# Altium schematic sheet BNT_Asma_Amp.SchDoc
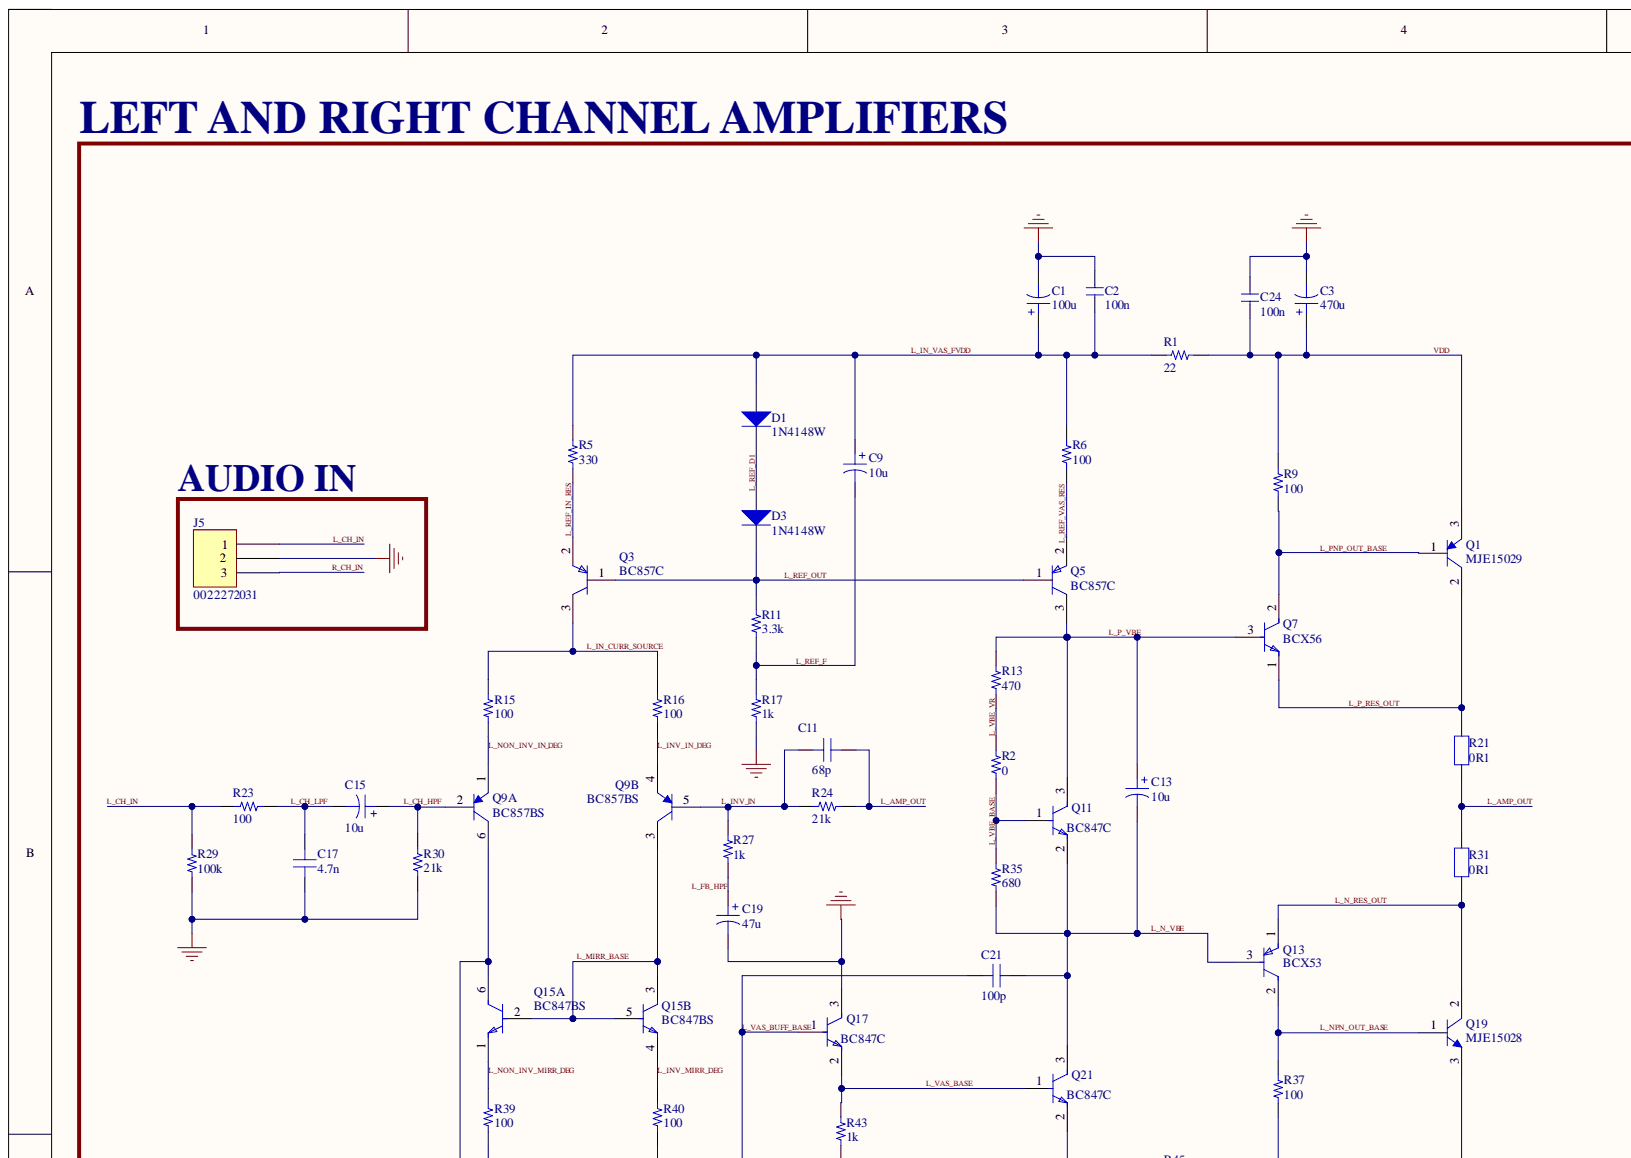
[diagram p0: BNT_Asma_Amp.SchDoc, top left]
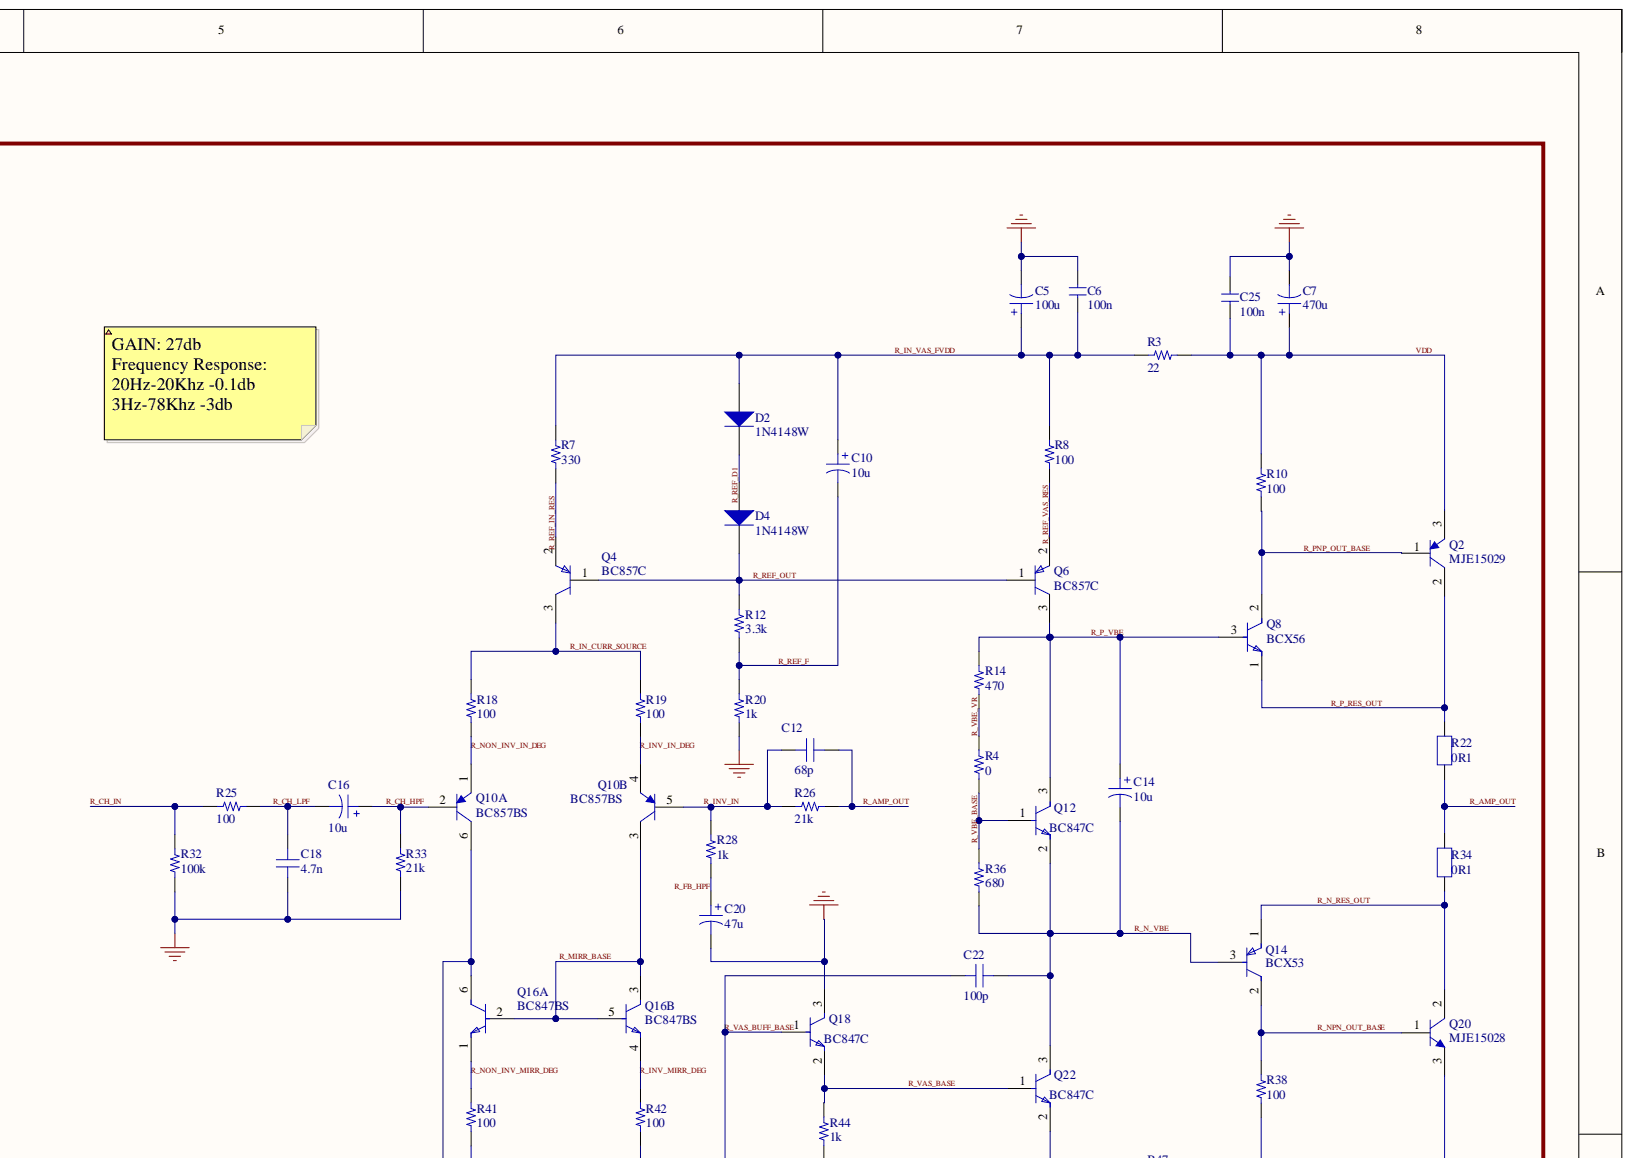
[diagram p1: BNT_Asma_Amp.SchDoc, top right]
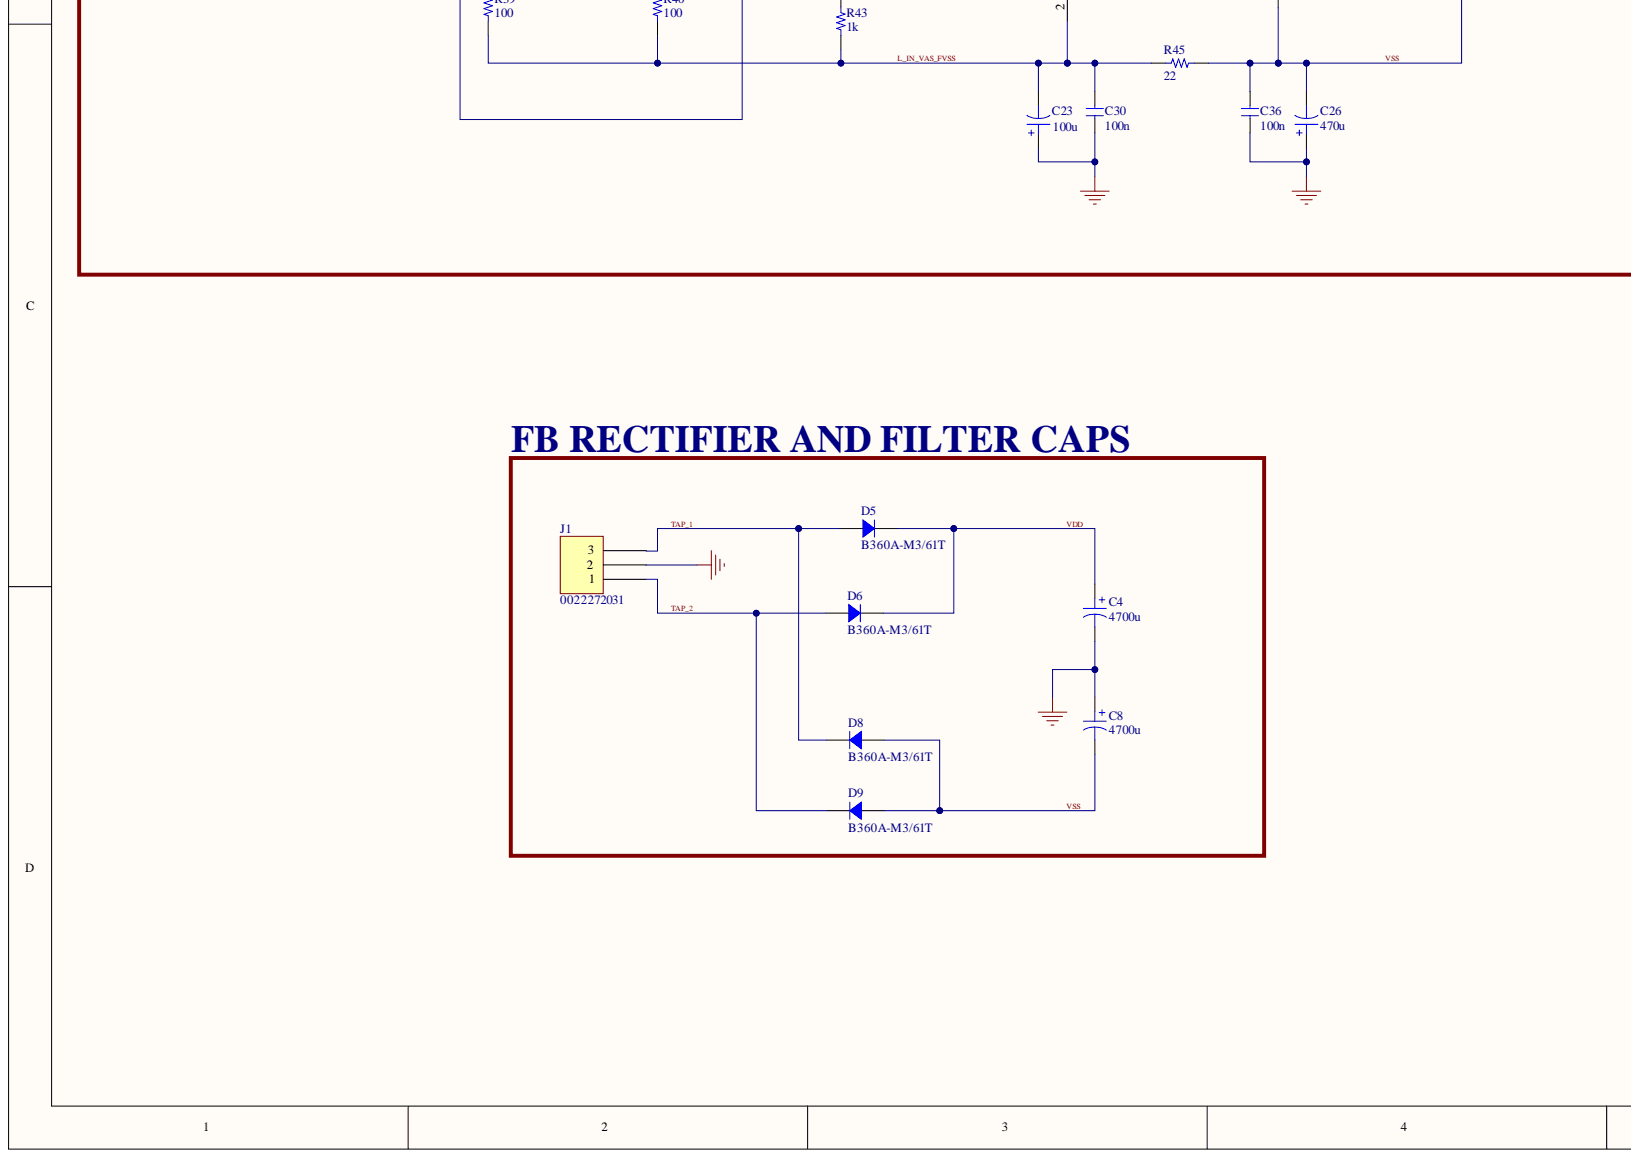
[diagram p2: BNT_Asma_Amp.SchDoc, bottom left]
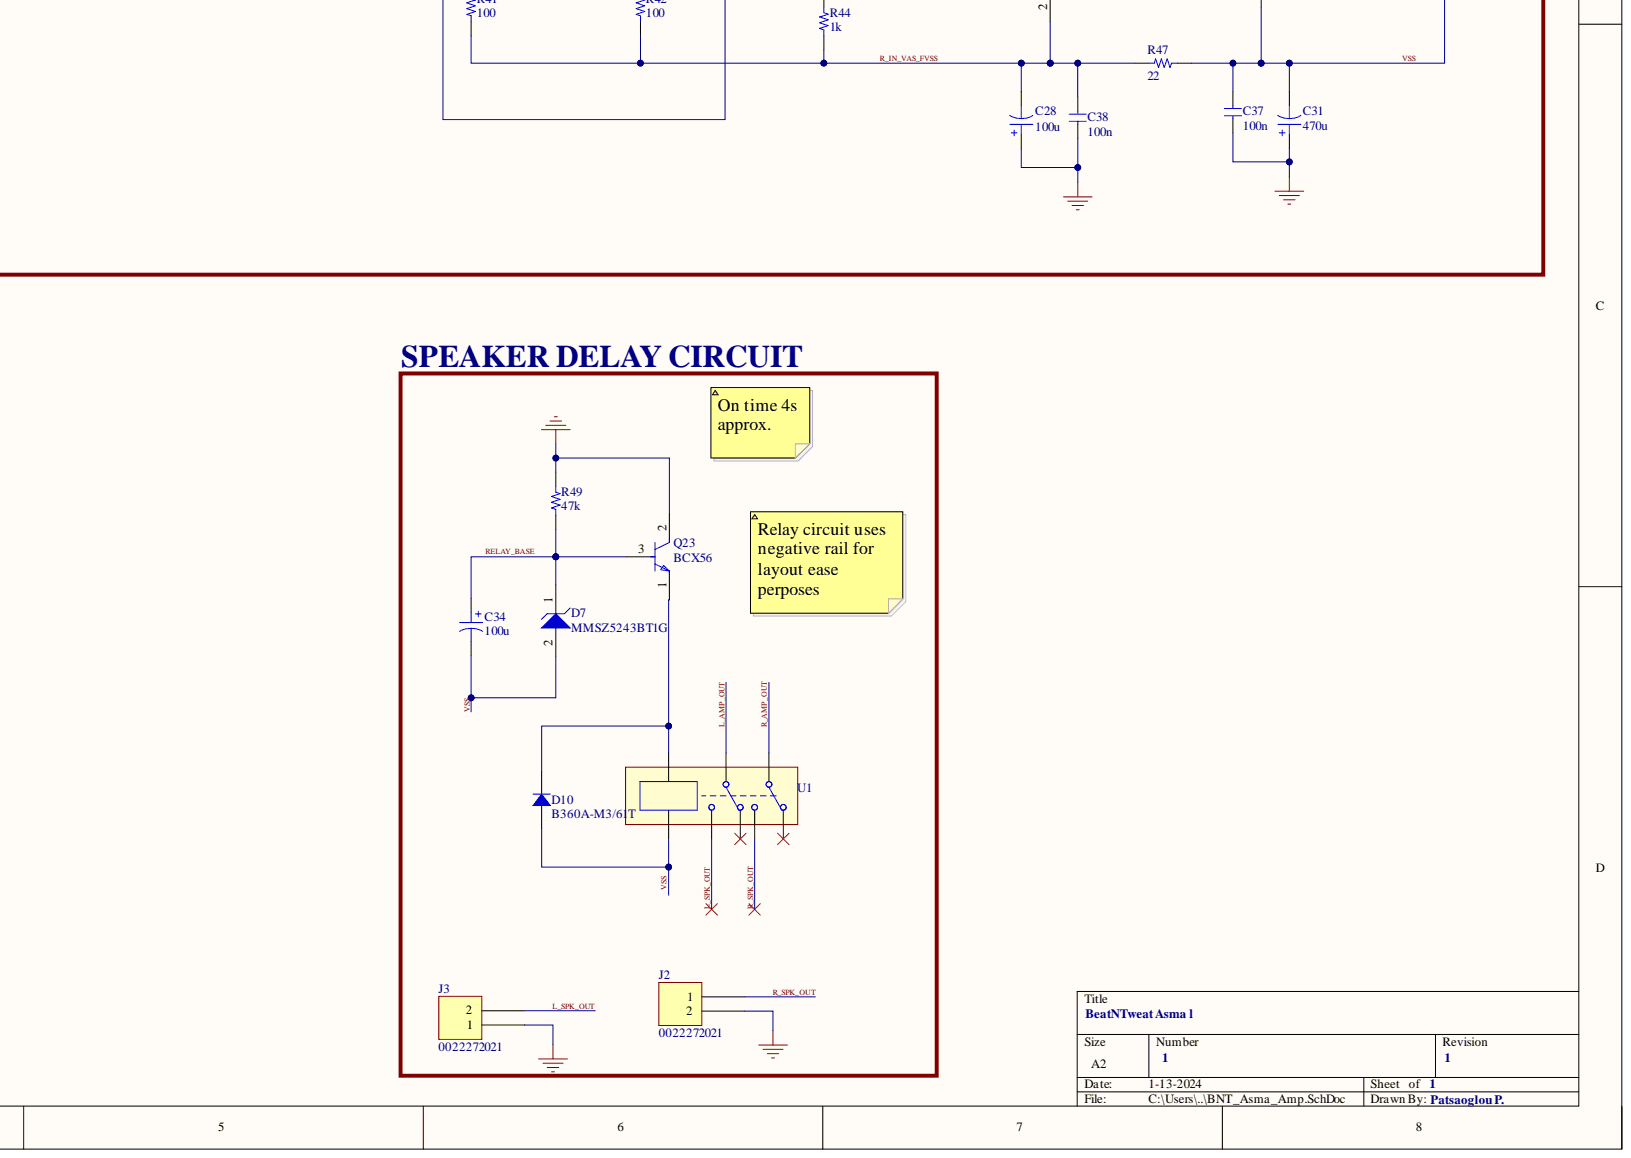
[diagram p3: BNT_Asma_Amp.SchDoc, bottom right]

## Parts
C1  100u  [CAP TH ALUM ELEC D6.30mm S2.50mm H11.00mm]
C2  100n  [POLY CAP 5MM]
C3  470u  [CAP TH ALUM ELEC D10.00mm S5.00mm H20.00mm]
C4  4700u  [CAP TH ALUM ELEC D18.00mm S7.50mm H37.50mm]
C5  100u  [CAP TH ALUM ELEC D6.30mm S2.50mm H11.00mm]
C6  100n  [POLY CAP 5MM]
C7  470u  [CAP TH ALUM ELEC D10.00mm S5.00mm H20.00mm]
C8  4700u  [CAP TH ALUM ELEC D18.00mm S7.50mm H37.50mm]
C9  10u  [CAP TH ALUM ELEC D5.00mm S2.00mm H12.50mm]
C10  10u  [CAP TH ALUM ELEC D5.00mm S2.00mm H12.50mm]
C11  68p  [CAP 0805_2012]
C12  68p  [CAP 0805_2012]
C13  10u  [CAP TH ALUM ELEC D5.00mm S2.00mm H12.50mm]
C14  10u  [CAP TH ALUM ELEC D5.00mm S2.00mm H12.50mm]
C15  10u  [CAP TH ALUM ELEC D5.00mm S2.00mm H12.50mm]
C16  10u  [CAP TH ALUM ELEC D5.00mm S2.00mm H12.50mm]
C17  4.7n  [CAP 0805_2012]
C18  4.7n  [CAP 0805_2012]
C19  47u  [CAP TH ALUM ELEC D5.00mm S2.00mm H12.50mm]
C20  47u  [CAP TH ALUM ELEC D5.00mm S2.00mm H12.50mm]
C21  100p  [CAP 0805_2012]
C22  100p  [CAP 0805_2012]
C23  100u  [CAP TH ALUM ELEC D6.30mm S2.50mm H11.00mm]
C24  100n  [POLY CAP 5MM]
C25  100n  [POLY CAP 5MM]
C26  470u  [CAP TH ALUM ELEC D10.00mm S5.00mm H20.00mm]
C28  100u  [CAP TH ALUM ELEC D6.30mm S2.50mm H11.00mm]
C30  100n  [POLY CAP 5MM]
C31  470u  [CAP TH ALUM ELEC D10.00mm S5.00mm H20.00mm]
C34  100u  [CAP TH ALUM ELEC D6.30mm S2.50mm H11.00mm]
C36  100n  [POLY CAP 5MM]
C37  100n  [POLY CAP 5MM]
C38  100n  [POLY CAP 5MM]
D1  1N4148W  [DIOD-SOD123-2_V]
D2  1N4148W  [DIOD-SOD123-2_V]
D3  1N4148W  [DIOD-SOD123-2_V]
D4  1N4148W  [DIOD-SOD123-2_V]
D5  B360A-M3/61T  [DO214AC SMA]
D6  B360A-M3/61T  [DO214AC SMA]
D7  MMSZ5243BT1G  [ONSC-SOD-123-2-425-04_V]
D8  B360A-M3/61T  [DO214AC SMA]
D9  B360A-M3/61T  [DO214AC SMA]
D10  B360A-M3/61T  [DO214AC SMA]
J1  0022272031  [MOLEX KK 0022272031]
J2  0022272021  [MOLEX KK 0022272021]
J3  0022272021  [MOLEX KK 0022272021]
J5  0022272031  [MOLEX KK 0022272031]
Q1  MJE15029  [ONSC-TO-220-3-221A-09]
Q2  MJE15029  [ONSC-TO-220-3-221A-09]
Q3  BC857C  [FP-SOT23-IPC_B]
Q4  BC857C  [FP-SOT23-IPC_B]
Q5  BC857C  [FP-SOT23-IPC_B]
Q6  BC857C  [FP-SOT23-IPC_B]
Q7  BCX56  [FP-SOT89-MFG]
Q8  BCX56  [FP-SOT89-MFG]
Q9  BC857BS  [NXP-SOT363-6_V]  (parts A,B)
Q10  BC857BS  [NXP-SOT363-6_V]  (parts A,B)
Q11  BC847C  [FP-SOT23-MFG]
Q12  BC847C  [FP-SOT23-MFG]
Q13  BCX53  [FP-SOT89-MFG]
Q14  BCX53  [FP-SOT89-MFG]
Q15  BC847BS  [BC847BS]  (parts A,B)
Q16  BC847BS  [BC847BS]  (parts A,B)
Q17  BC847C  [FP-SOT23-MFG]
Q18  BC847C  [FP-SOT23-MFG]
Q19  MJE15028  [ONSC-TO-220-3-221A-09]
Q20  MJE15028  [ONSC-TO-220-3-221A-09]
Q21  BC847C  [FP-SOT23-MFG]
Q22  BC847C  [FP-SOT23-MFG]
Q23  BCX56  [FP-SOT89-MFG]
R1  22  [RES 0805_2012]
R2  0  [RES 0805_2012]
R3  22  [RES 0805_2012]
R4  0  [RES 0805_2012]
R5  330  [RES 0805_2012]
R6  100  [RES 0805_2012]
R7  330  [RES 0805_2012]
R8  100  [RES 0805_2012]
R9  100  [RES 0805_2012]
R10  100  [RES 0805_2012]
R11  3.3k  [RES 0805_2012]
R12  3.3k  [RES 0805_2012]
R13  470  [RES 0805_2012]
R14  470  [RES 0805_2012]
R15  100  [RES 0805_2012]
R16  100  [RES 0805_2012]
R17  1k  [RES 0805_2012]
R18  100  [RES 0805_2012]
R19  100  [RES 0805_2012]
R20  1k  [RES 0805_2012]
R21  value 0R1  [5W_CEMENT_R_VERTICAL]  (hidden comment: 5W_CEMENT_R)
R22  value 0R1  [5W_CEMENT_R_VERTICAL]  (hidden comment: 5W_CEMENT_R)
R23  100  [RES 0805_2012]
R24  21k  [RES 0805_2012]
R25  100  [RES 0805_2012]
R26  21k  [RES 0805_2012]
R27  1K  [RES 0805_2012]
R28  1K  [RES 0805_2012]
R29  100k  [RES 0805_2012]
R30  21k  [RES 0805_2012]
R31  value 0R1  [5W_CEMENT_R_VERTICAL]  (hidden comment: 5W_CEMENT_R)
R32  100k  [RES 0805_2012]
R33  21k  [RES 0805_2012]
R34  value 0R1  [5W_CEMENT_R_VERTICAL]  (hidden comment: 5W_CEMENT_R)
R35  680  [RES 0805_2012]
R36  680  [RES 0805_2012]
R37  100  [RES 0805_2012]
R38  100  [RES 0805_2012]
R39  100  [RES 0805_2012]
R40  100  [RES 0805_2012]
R41  100  [RES 0805_2012]
R42  100  [RES 0805_2012]
R43  1K  [RES 0805_2012]
R44  1K  [RES 0805_2012]
R45  22  [RES 0805_2012]
R47  22  [RES 0805_2012]
R49  value 47k  [RES 0805_2012]  (hidden comment: 1K)
U1  Relay DPDT  [RT424012]  (value not drawn)

## Nets
GND: C1.2 C2.2 C3.2 C4.2 C5.2 C6.2 C7.2 C8.1 C17.1 C18.1 C19.2 C20.2 C23.1 C24.2 C25.2 C26.1 C28.1 C30.1 C31.1 C36.1 C37.1 C38.1 J1.2 J2.2 J3.1 J5.2 Q17.3 Q18.3 Q23.2 R17.2 R20.2 R29.1 R30.2 R32.1 R33.2 R49.1
L_AMP_OUT: C11.1 R21.1 R24.2 R31.2 U1.6
L_CH_HPF: C15.1 Q9.2 R30.1
L_CH_IN: J5.1 R23.2 R29.2
L_CH_LPF: C15.2 C17.2 R23.1
L_FB_HPF: C19.1 R27.2
L_IN_CURR_SOURCE: Q3.3 R15.1 R16.1
L_IN_VAS_FVDD: C1.1 C2.1 C9.1 D1.1 R1.1 R5.1 R6.1
L_IN_VAS_FVSS: C23.2 C30.2 Q21.2 R39.2 R40.2 R43.2 R45.1
L_INV_IN: C11.2 Q9.5 R24.1 R27.1
L_INV_IN_DEG: Q9.4 R16.2
L_INV_MIRR_DEG: Q15.4 R40.1
L_MIRR_BASE: Q9.3 Q15.2 Q15.3 Q15.5
L_N_RES_OUT: Q13.1 Q19.2 R31.1
L_N_VBE: C13.2 C21.1 Q11.2 Q13.3 Q21.3 R35.2
L_NON_INV_IN_DEG: Q9.1 R15.2
L_NON_INV_MIRR_DEG: Q15.1 R39.1
L_NPN_OUT_BASE: Q13.2 Q19.1 R37.1
L_P_RES_OUT: Q1.2 Q7.1 R21.2
L_P_VBE: C13.1 Q5.3 Q7.3 Q11.3 R13.1
L_PNP_OUT_BASE: Q1.1 Q7.2 R9.1
L_REF_D1: D1.2 D3.1
L_REF_F: C9.2 R11.2 R17.1
L_REF_IN_RES: Q3.2 R5.2
L_REF_OUT: D3.2 Q3.1 Q5.1 R11.1
L_REF_VAS_RES: Q5.2 R6.2
L_SPK_OUT: J3.2 U1.8
L_VAS_BASE: Q17.2 Q21.1 R43.1
L_VAS_BUFF_BASE: C21.2 Q9.6 Q15.6 Q17.1
L_VBE_BASE: Q11.1 R2.2 R35.1
L_VBE_VR: R2.1 R13.2
R_AMP_OUT: C12.1 R22.1 R26.2 R34.2 U1.3
R_CH_HPF: C16.1 Q10.2 R33.1
R_CH_IN: J5.3 R25.2 R32.2
R_CH_LPF: C16.2 C18.2 R25.1
R_FB_HPF: C20.1 R28.2
R_IN_CURR_SOURCE: Q4.3 R18.1 R19.1
R_IN_VAS_FVDD: C5.1 C6.1 C10.1 D2.1 R3.1 R7.1 R8.1
R_IN_VAS_FVSS: C28.2 C38.2 Q22.2 R41.2 R42.2 R44.2 R47.1
R_INV_IN: C12.2 Q10.5 R26.1 R28.1
R_INV_IN_DEG: Q10.4 R19.2
R_INV_MIRR_DEG: Q16.4 R42.1
R_MIRR_BASE: Q10.3 Q16.2 Q16.3 Q16.5
R_N_RES_OUT: Q14.1 Q20.2 R34.1
R_N_VBE: C14.2 C22.1 Q12.2 Q14.3 Q22.3 R36.2
R_NON_INV_IN_DEG: Q10.1 R18.2
R_NON_INV_MIRR_DEG: Q16.1 R41.1
R_NPN_OUT_BASE: Q14.2 Q20.1 R38.1
R_P_RES_OUT: Q2.2 Q8.1 R22.2
R_P_VBE: C14.1 Q6.3 Q8.3 Q12.3 R14.1
R_PNP_OUT_BASE: Q2.1 Q8.2 R10.1
R_REF_D1: D2.2 D4.1
R_REF_F: C10.2 R12.2 R20.1
R_REF_IN_RES: Q4.2 R7.2
R_REF_OUT: D4.2 Q4.1 Q6.1 R12.1
R_REF_VAS_RES: Q6.2 R8.2
R_SPK_OUT: J2.1 U1.4
R_VAS_BASE: Q18.2 Q22.1 R44.1
R_VAS_BUFF_BASE: C22.2 Q10.6 Q16.6 Q18.1
R_VBE_BASE: Q12.1 R4.2 R36.1
R_VBE_VR: R4.1 R14.2
RELAY_BASE: C34.1 D7.1 Q23.3 R49.2
TAP_1: D5.1 D8.2 J1.3
TAP_2: D6.1 D9.2 J1.1
VDD: C3.1 C4.1 C7.1 C24.1 C25.1 D5.2 D6.2 Q1.3 Q2.3 R1.2 R3.2 R9.2 R10.2
VSS: C8.2 C26.2 C31.2 C34.2 C36.2 C37.2 D7.2 D8.1 D9.1 D10.1 Q19.3 Q20.3 R37.2 R38.2 R45.2 R47.2 U1.2
NetD10_2: D10.2 Q23.1 U1.1

Not connected: U1 5 7

## Library data of the parts
C1 C5 C23 C28 C34 = UKW1V101MED: CAP ALUM 100UF 20% 35V RADIAL; manufacturer: Nichicon; Capacitance: 100µF; Database Table Name: Capacitors - Aluminum - Electrolytic TH; Lifetime @ Temp.: 2000 Hrs @ 85°C; Polarization: Polar; Ripple Current @ High Frequency: 300mA @ 10kHz; Ripple Current @ Low Frequency: 200mA @ 120Hz; value: 100µF; Applications: Audio; Component Kind: Standard; componentlink1description: Datasheet; componentlink1url: http://nichicon-us.com/english/products/pdfs/e-ukw.pdf; componentlink2description: DigiKey Link; componentlink2url: http://digikey.com/product-detail/en/nichicon/UKW1V101MED/493-15506-ND/1964242; Device Package: Radial, Can; Height - Seated (Max): 0.433" (11.00mm); lastupdated: 04-Sep-20 1:05:43 AM; Lead Spacing: 0.098" (2.50mm); Library Name: Celestial Altium Library - altium_library.DbLib; Lifecycle Status: Active; Minimum Order: 1; Mounting Type: Through Hole; Operating Temperature: -40°C ~ 85°C; Package / Case: Radial, Can; packaging: Bulk; Part Number: UKW1V101MED; Part Status: Active; partid: 19950; price: 0.47; series: UKW; Size / Dimension: 0.248" Dia (6.30mm); Supplier 1: DigiKey; Supplier Part Number 1: 493-15506-ND; Tolerance: ±20%; Voltage - Rated: 35V
C2 C6 C24 C25 C30 C36-C38 = POLY CAP: Generic TH Polyester Capacitor 5mm; Component Kind: Standard; Library Name: CustomComponentLibraries.SchLib
C3 C7 C26 C31 = EEU-FC1V471: CAP ALUM 470UF 20% 35V RADIAL; manufacturer: Panasonic Electronic Components; Capacitance: 470µF; Database Table Name: Capacitors - Aluminum - Electrolytic TH; Impedance: 52 mOhms; Lifetime @ Temp.: 3000 Hrs @ 105°C; Polarization: Polar; Ripple Current @ High Frequency: 1.22A @ 100kHz; Ripple Current @ Low Frequency: 915mA @ 120Hz; value: 470µF; Applications: Automotive; Component Kind: Standard; componentlink1description: Datasheet; componentlink1url: http://industrial.panasonic.com/www-cgi/jvcr13pz.cgi?E+PZ+3+ABA0022+EEUFC1V471+7+WW; componentlink2description: DigiKey Link; componentlink2url: http://digikey.com/product-detail/en/panasonic-electronic-components/EEU-FC1V471/P10301-ND/266310; Device Package: Radial, Can; Height - Seated (Max): 0.787" (20.00mm); lastupdated: 02-Sep-20 1:31:31 AM; Lead Spacing: 0.197" (5.00mm); Library Name: Celestial Altium Library - altium_library.DbLib; Lifecycle Status: Active; Minimum Order: 1; Mounting Type: Through Hole; Operating Temperature: -55°C ~ 105°C; Package / Case: Radial, Can; packaging: Bulk; Part Number: EEU-FC1V471; Part Status: Active; partid: 12504; price: 0.75; Ratings: AEC-Q200; series: FC; Size / Dimension: 0.394" Dia (10.00mm); Supplier 1: DigiKey; Supplier Part Number 1: P10301-ND; Tolerance: ±20%; Voltage - Rated: 35V
C4 C8 = ECA-1VM472: CAP ALUM 4700UF 20% 35V RADIAL; manufacturer: Panasonic Electronic Components; Capacitance: 4700µF; Database Table Name: Capacitors - Aluminum - Electrolytic TH; Lifetime @ Temp.: 2000 Hrs @ 85°C; Polarization: Polar; Ripple Current @ High Frequency: 2.72A @ 10kHz; Ripple Current @ Low Frequency: 1.6A @ 120Hz; value: 4700µF; Applications: General Purpose; Component Kind: Standard; componentlink1description: Datasheet; componentlink1url: //media.digikey.com/pdf/Data%20Sheets/Panasonic%20Electronic%20Components/ECA-xxM%20Series,TypeA.pdf; componentlink2description: DigiKey Link; componentlink2url: http://digikey.com/product-detail/en/panasonic-electronic-components/ECA-1VM472/P5172-ND/245031; Device Package: Radial, Can; Height - Seated (Max): 1.476" (37.50mm); lastupdated: 02-Sep-20 1:33:31 AM; Lead Spacing: 0.295" (7.50mm); Library Name: Celestial Altium Library - altium_library.DbLib; Lifecycle Status: Active; Minimum Order: 1; Mounting Type: Through Hole; Operating Temperature: -40°C ~ 85°C; Package / Case: Radial, Can; packaging: Bulk; Part Number: ECA-1VM472; Part Status: Active; partid: 18881; price: 1.91; series: M; Size / Dimension: 0.709" Dia (18.00mm); Supplier 1: DigiKey; Supplier Part Number 1: P5172-ND; Tolerance: ±20%; Voltage - Rated: 35V
C9 C10 C13-C16 = 860020372001: CAP ALUM 10UF 20% 16V RADIAL; manufacturer: Würth Elektronik; Capacitance: 10µF; Database Table Name: Capacitors - Aluminum - Electrolytic TH; Lifetime @ Temp.: 2000 Hrs @ 105°C; Polarization: Polar; Ripple Current @ High Frequency: 59.5mA @ 100kHz; Ripple Current @ Low Frequency: 35mA @ 120Hz; value: 10µF; Applications: General Purpose; Component Kind: Standard; componentlink1description: Datasheet; componentlink1url: https://katalog.we-online.de/pbs/datasheet/860020372001.pdf; componentlink2description: DigiKey Link; componentlink2url: http://digikey.com/product-detail/en/w-rth-elektronik/860020372001/732-8788-1-ND/5728733; Device Package: Radial, Can; Height - Seated (Max): 0.492" (12.50mm); lastupdated: 04-Sep-20 11:29:03 PM; Lead Spacing: 0.079" (2.00mm); Library Name: Celestial Altium Library - altium_library.DbLib; Lifecycle Status: Active; Minimum Order: 1; Mounting Type: Through Hole; Operating Temperature: -40°C ~ 105°C; Package / Case: Radial, Can; packaging: TapeAndBox; Part Number: 860020372001; Part Status: Active; partid: 22434; price: 0.05; series: WCAP-ATG5; Size / Dimension: 0.197" Dia (5.00mm); Supplier 1: DigiKey; Supplier Part Number 1: 732-8788-1-ND; Tolerance: ±20%; Voltage - Rated: 16V
C11 C12 C17 C18 C21 C22 = MM05ZC105KCZ2A: CAP CER 1UF 10V X7R 0805; manufacturer: AVX Corporation; Capacitance: 1µF; Database Table Name: Capacitors - Ceramic - 0805; Tolerance: ±10%; Applications: Medical, Non-Critical; Component Kind: Standard; componentlink1description: Datasheet; componentlink1url: http://datasheets.avx.com/AVX-MM.pdf; componentlink2description: DigiKey Link; componentlink2url: http://digikey.com/product-detail/en/avx-corporation/MM05ZC105KCZ2A/478-10611-1-ND/7344872; Device Package: 0805 (2012 Metric); lastupdated: 02-Sep-20 1:04:42 AM; Library Name: Celestial Altium Library - altium_library.DbLib; Lifecycle Status: Active; Minimum Order: 1; Mounting Type: Surface Mount, MLCC; Operating Temperature: -55°C ~ 125°C; Package / Case: 0805 (2012 Metric); packaging: TapeAndReel; Part Number: MM05ZC105KCZ2A; Part Status: Active; partid: 2439; price: 0.50; series: MM; Size / Dimension: 0.079" L x 0.049" W (2.01mm x 1.25mm); Supplier 1: DigiKey; Supplier Part Number 1: 478-10611-1-ND; Temperature Coefficient: X7R; Thickness (Max): 0.029" (0.74mm); Voltage - Rated: 10V
  C11 C12: Value: 68p
  C17 C18: Value: 4.7n
  C21 C22: Value: 100p
C19 C20 = UHV1V470MDD: CAP ALUM 47UF 20% 35V RADIAL; manufacturer: Nichicon; Capacitance: 47µF; Database Table Name: Capacitors - Aluminum - Electrolytic TH; Impedance: 230 mOhms; Lifetime @ Temp.: 5000 Hrs @ 105°C; Polarization: Polar; Ripple Current @ High Frequency: 360mA @ 100kHz; Ripple Current @ Low Frequency: 144mA @ 120Hz; value: 47µF; Applications: General Purpose; Component Kind: Standard; componentlink1description: Datasheet; componentlink1url: http://nichicon-us.com/english/products/pdfs/e-uhv.pdf; componentlink2description: DigiKey Link; componentlink2url: http://digikey.com/product-detail/en/nichicon/UHV1V470MDD/493-15312-ND/2598282; Device Package: Radial, Can; Height - Seated (Max): 0.492" (12.50mm); lastupdated: 04-Sep-20 11:28:59 PM; Lead Spacing: 0.079" (2.00mm); Library Name: Celestial Altium Library - altium_library.DbLib; Lifecycle Status: Active; Minimum Order: 1; Mounting Type: Through Hole; Operating Temperature: -40°C ~ 105°C; Package / Case: Radial, Can; packaging: Bulk; Part Number: UHV1V470MDD; Part Status: Active; partid: 21866; price: 0.33; series: UHV; Size / Dimension: 0.197" Dia (5.00mm); Supplier 1: DigiKey; Supplier Part Number 1: 493-15312-ND; Tolerance: ±20%; Voltage - Rated: 35V
D1-D4 = CMP-2000-04932-2: Fast Switching Diode, 100 V, 0.15 A, -65 to 150 degC, 2-Pin?SOD123, RoHS, Tape and Reel; Brand: DiodesIncorporated; Configuration: Single; If - Forward Current: 0.15A; Ir - Reverse Current: 1uA; Max Surge Current: 2A; Peak Reverse Voltage: 100V; Recovery Time: 4ns; Unit Weight: 0.000353oz; Vf - Forward Voltage: 1.25V; Component Kind: Standard; Datasheet URL: http://www.farnell.com/datasheets/1915529.pdf; Datasheet Version: Rev. 24-2, 10/2013; Factory Pack Quantity: 3000; Height: 1.25mm; Length: 2.85mm; Library Name: Altium Content Vault; Manufacturer: DiodesIncorporated; Manufacturer URL: http://www.diodes.com/; Maximum Operating Temperature: +150C; Minimum Operating Temperature: -65C; Mounting Style: SMD/SMT; Operating Temperature Range: -65Cto+150C; Package / Case: SOD-123; Package Reference: SOD123-2; Package URL: http://www.diodes.com/datasheets/ds18004.pdf; Packaging: Reel; Product: SwitchingDiodes; Product Category: Diodes-GeneralPurpose,Power,Switching; RoHS: True; Series: 1N4148; Type: SwitchingDiode; Width: 1.7mm
D5 D6 D8-D10 = B360A-M3/61T: DIODE SCHOTTKY 60V 3A DO214AC; Manufacturer: Vishay Semiconductor Diodes Division; Capacitance @ Vr, F: 145pF @ 4V, 1MHz; Current - Reverse Leakage @ Vr: 200µA @ 60V; Database Table Name: Diodes - Rectifiers - Single; Speed: Fast Recovery =< 500ns, > 200mA (Io); Voltage - Forward (Vf) (Max) @ If: 720mV @ 3A; Component Kind: Standard; ComponentLink1Description: Datasheet; ComponentLink1URL: http://www.vishay.com/docs/89414/b350a-m3.pdf; ComponentLink2Description: DigiKey Link; ComponentLink2URL: http://digikey.com/product-detail/en/vishay-semiconductor-diodes-division/B360A-M3-61T/B360A-M3-61TGICT-ND/8564655; Current - Average Rectified (Io): 3A; Diode Type: Schottky; LastUpdated: 29-Dec-23 9:23:09 PM; Library Name: Celestial Altium Library - altium_library.DbLib; Lifecycle Status: Active; Minimum Order: 1; Mounting Type: Surface Mount; Operating Temperature - Junction: -55°C ~ 150°C; Package / Case: DO-214AC, SMA; Packaging: TapeAndReel; Part Number: B360A-M3/61T; Part Status: Active; PartId: 2018; Price: 0.16; Series: -; Supplier 1: DigiKey; Supplier Device Package: DO-214AC (SMA); Supplier Part Number 1: B360A-M3/61TGICT-ND; Voltage - DC Reverse (Vr) (Max): 60V
D7 = CMP-1060-00543-1: Zener Voltage Regulator, 500 mW, 2-Pin SOD-123, Pb-Free, Tape and Reel; Manufacturer: On Semiconductor; P Max (W): 0.5; VZ Typ (V): 13; Component Kind: Standard; ComponentLink1Description: Manufacturer URL; ComponentLink1URL: http://www.onsemi.com/; ComponentLink2Description: Datasheet; ComponentLink2URL: http://www.onsemi.com/pub_link/Collateral/MMSZ5221BT1-D.PDF; ComponentLink3Description: Package Specification; ComponentLink3URL: http://www.onsemi.com/pub_link/Collateral/425-04.PDF; DatasheetVersion: Rev. 12; Library Name: Altium Content Vault; Mounting Technology: Surface Mount; PackageDescription: 2-Pin SOD, Body 1.6 x 2.69 mm; PackageReference: SOD-123-2-425-04; PackageVersion: Rev. G, 10/2009; Packing: Tape and Reel; PartNumber: MMSZ5243BT1G; RoHS: Pb-Free
J1 J5 = 0022272031: CONN HEADER VERT 3POS 2.54MM; Manufacturer: Molex; Contact Length - Mating: 0.295" (7.49mm); Contact Length - Post: 0.140" (3.56mm); Database Table Name: Connectors - Rectangular Headers Male Pins; Material Flammability Rating: UL94 V-0; Number of Positions: 3; Number of Positions Loaded: All; Overall Contact Length: 0.560" (14.22mm); Pitch - Mating: 0.100" (2.54mm); Shrouding: Shrouded - 1 Wall; Style: Board to Cable/Wire; Component Kind: Standard; ComponentLink1Description: Datasheet; ComponentLink1URL: https://www.molex.com/pdm_docs/sd/022272031_sd.pdf; ComponentLink2Description: DigiKey Link; ComponentLink2URL: http://digikey.com/product-detail/en/molex/0022272031/WM4112-ND/1130578; Connector Type: Header; Contact Finish - Mating: Tin; Contact Finish - Post: Tin; Contact Finish Thickness - Mating: 100.0µin (2.54µm); Contact Material: Brass; Contact Shape: Square; Contact Type: Male Pin; Fastening Type: Friction Lock; Insulation Color: Natural; Insulation Height: 0.461" (11.70mm); Insulation Material: Polyamide (PA), Nylon; LastUpdated: 12-Jan-20 11:51:54 PM; Library Name: Celestial Altium Library - altium_library.DbLib; Lifecycle Status: Active; Minimum Order: 1; Mounting Type: Through Hole; Number of Rows: 1; Packaging: Bulk; Part Number: 0022272031; Part Status: Active; PartId: 77; Price: 0.37; Series: KK 6410; Supplier 1: DigiKey; Supplier Part Number 1: WM4112-ND; Termination: Solder
J2 J3 = 0022272021: CONN HEADER VERT 2POS 2.54MM; Manufacturer: Molex; Contact Length - Mating: 0.295" (7.49mm); Contact Length - Post: 0.140" (3.56mm); Database Table Name: Connectors - Rectangular Headers Male Pins; Material Flammability Rating: UL94 V-0; Number of Positions: 2; Number of Positions Loaded: All; Overall Contact Length: 0.560" (14.22mm); Pitch - Mating: 0.100" (2.54mm); Shrouding: Shrouded - 1 Wall; Style: Board to Cable/Wire; Component Kind: Standard; ComponentLink1Description: Datasheet; ComponentLink1URL: https://www.molex.com/pdm_docs/sd/022272021_sd.pdf; ComponentLink2Description: DigiKey Link; ComponentLink2URL: http://digikey.com/product-detail/en/molex/0022272021/WM4111-ND/1130577; Connector Type: Header; Contact Finish - Mating: Tin; Contact Finish - Post: Tin; Contact Finish Thickness - Mating: 100.0µin (2.54µm); Contact Material: Brass; Contact Shape: Square; Contact Type: Male Pin; Fastening Type: Friction Lock; Insulation Color: Natural; Insulation Height: 0.461" (11.70mm); Insulation Material: Polyamide (PA), Nylon; LastUpdated: 12-Jan-20 11:51:43 PM; Library Name: Celestial Altium Library - altium_library.DbLib; Lifecycle Status: Active; Minimum Order: 1; Mounting Type: Through Hole; Number of Rows: 1; Packaging: Bulk; Part Number: 0022272021; Part Status: Active; PartId: 76; Price: 0.27; Series: KK 6410; Supplier 1: DigiKey; Supplier Part Number 1: WM4111-ND; Termination: Solder
Q1 Q2 = CMP-1030-00016-1: Complementary Silicon Plastic Power Transistor, 3-Pin TO-220, Tube; Manufacturer: On Semiconductor; fT Min (MHz): 30; IC Continuous (A): 8; Polarity: PNP; Component Kind: Standard; ComponentLink1Description: Manufacturer URL; ComponentLink1URL: http://www.onsemi.com/; ComponentLink2Description: Datasheet; ComponentLink2URL: http://www.onsemi.cn/pub_link/Collateral/MJE15028-D.PDF; ComponentLink3Description: Package Specification; ComponentLink3URL: http://www.onsemi.com/pub_link/Collateral/221A-09.PDF; DatasheetVersion: Rev. 6; Library Name: Altium Content Vault; Mounting Technology: Through Hole; PackageDescription: 3-Pin Flange Mount, Vertical Straight Lead, Body 9.97 x 4.445 mm, Pitch 2.54 mm; PackageReference: TO-220-3-221A-09; PackageVersion: Rev. AG, 09/2011; Packing: Tube; PartNumber: MJE15029; RoHS: FALSE
Q3-Q6 = CMP-14406-000034-1: TRANS PNP 45V 0.1A SOT23; Component Kind: Standard; Library Name: Altium Content Vault; RoHS Compliant: Yes
Q7 Q8 Q23 = CMP-14406-000032-1: TRANS NPN 80V 1A SOT89; Component Kind: Standard; Library Name: Altium Content Vault
Q9 Q10 = CMP-2000-05938-1: PNP General Purpose Double Transistor, 200 mW, -65 to 150 degC, 6-Pin SOT363, RoHS, Tape and Reel; Manufacturer: NXP Semiconductors; Collector Emitter Voltage V(br)ceo: 45V; DC Collector Current: -100mA; DC Current Gain hFE: 200hFE; No. of Pins: 6Pins; Package Version: 02/2016; Power Dissipation Pd: 200mW; Transistor Case Style: SC-88; Automotive Qualification Standard: -; Component Kind: Standard; Datasheet URL: http://cache.nxp.com/documents/data_sheet/BC857BS.pdf; Datasheet Version: 04/1999; Library Name: Altium Content Vault; Manufacturer URL: http://www.nxp.com/; Mounting Technology: SurfaceMount; Operating Temperature Max: 150°C; Package Description: 6-Pin Small Outline Transistor Package, Body 2 x 1.25 mm, Pitch 0.65 mm; Package Reference: SOT363; Package URL: http://www.nxp.com/documents/outline_drawing/SOT363.pdf; Product Range: -; Transistor Polarity: PNP
Q11 Q12 Q17 Q18 Q21 Q22 = CMP-14406-000033-1: TRANS NPN 45V 100MA TO236AB; Component Kind: Standard; Library Name: Altium Content Vault; RoHS Compliant: Yes
Q13 Q14 = CMP-14406-000013-1: BCX53-16T/SOT89/MPT3; Manufacturer: Nexperia; Manufacturer Part Number: BCX53-16TF; Automotive Grade: Grade 0; Collector Base Voltage: -100V; Collector Emitter Breakdown Voltage: -80V; Collector Emitter Saturation Voltage: -500mV; Emitter Base Voltage: -5V; Hfe Max: 250; Hfe Min: 100; Hfe Testic: -150mA; Hfe Testvce: -2V; Max Collector Current: -1A; Max Junction Temp: +150°C; Number Of Elements: 1; Power Dissipation: 500mW; Rthja Max: 250K/W; Unity Gain Freq: 140MHz; Ambient Temperature Range High: +150°C; Ambient Temperature Range Low: -55°C; Automotive: Yes; Category: Trans; Collector Emitter Voltage: -80V; Component Kind: Standard; ComponentLink2Description: Datasheet; ComponentLink2URL: https://assets.nexperia.com/documents/data-sheet/BCX53T_SER.pdf; Device Class L1: Discrete Semiconductors; Device Class L2: Transistors; Device Class L3: BJTs; Digikey Description: BCX53-16T/SOT89/MPT3; Footprint Url: https://assets.nexperia.com/documents/outline-drawing/SOT89.pdf; Height: 1.6mm; Lead Free: Yes; Library Name: Altium Content Vault; Number Of Pnp: 1; Octopart: https://octopart.com/bcx53-16tf-nexperia-104793180; Package: SOT89; RoHS: TRUE; Temperature: to +150°C; Temperature Range High: +150°C
Q15 Q16 = BC847BS: NEXPERIA BC847BS; Component Kind: Standard; Library Name: CustomComponentLibraries.SchLib
Q19 Q20 = CMP-1046-00078-1: Complementary Silicon Plastic Power Transistor, 3-Pin TO-220, Tube; Manufacturer: On Semiconductor; fT Min (MHz): 30; IC Continuous (A): 8; Polarity: NPN; Component Kind: Standard; ComponentLink1Description: Manufacturer URL; ComponentLink1URL: http://www.onsemi.com/; ComponentLink2Description: Datasheet; ComponentLink2URL: http://www.onsemi.cn/pub_link/Collateral/MJE15028-D.PDF; ComponentLink3Description: Package Specification; ComponentLink3URL: http://www.onsemi.com/pub_link/Collateral/221A-09.PDF; DatasheetVersion: Rev. 6; Library Name: Altium Content Vault; Mounting Technology: Through Hole; PackageDescription: 3-Pin Flange Mount, Vertical Straight Lead, Body 9.97 x 4.445 mm, Pitch 2.54 mm; PackageReference: TO-220-3-221A-09; PackageVersion: Rev. AG, 09/2011; Packing: Tube; PartNumber: MJE15028; RoHS: FALSE
R1-R20 R23-R30 R32 R33 R35-R45 R47 R49 = CPF0805B100KE: RES SMD 100K OHM 0.1% 1/10W 0805; Manufacturer: TE Connectivity Passive Product; Database Table Name: Resistors - Surface Mount - 0805; Power (Watts): 0.1W, 1/10W; Resistance: 100 kOhms; Temperature Coefficient: ±25ppm/°C; Component Kind: Standard; ComponentLink1Description: Datasheet; ComponentLink1URL: https://www.te.com/commerce/DocumentDelivery/DDEController?Action=srchrtrv&DocNm=1773200&DocType=DS&DocLang=English; ComponentLink2Description: DigiKey Link; ComponentLink2URL: https://www.digikey.com/en/products/detail/te-connectivity-passive-product/CPF0805B100KE/2389788; Composition: Thin Film; Device Package: 0805 (2012 Metric); Height - Seated (Max): 0.026" (0.65mm); LastUpdated: 27-Dec-23 9:00:17 PM; Library Name: Celestial Altium Library - altium_library.DbLib; Lifecycle Status: Active; Minimum Order: 1; Number of Terminations: 2; Operating Temperature: -55°C ~ 155°C; Package / Case: 0805 (2012 Metric); Packaging: TapeAndReel; Part Number: CPF0805B100KE; Part Status: Active; PartId: 27729; Price: 0.09; Series: CPF, Neohm; Size / Dimension: 0.079" L x 0.049" W (2.00mm x 1.25mm); Supplier 1: DigiKey; Supplier Device Package: 0805; Supplier Part Number 1: A106042CT-ND; Tolerance: ±0.1%
  R1 R3 R45 R47: Value: 22
  R2 R4: Value: 0
  R5 R7: Value: 330
  R6 R8-R10 R15 R16 R18 R19 R23 R25 R37-R42: Value: 100
  R11 R12: Value: 3.3k
  R13 R14: Value: 470
  R17 R20 R27 R28 R43 R44: Value: 1k
  R24 R26 R30 R33: Value: 21k
  R29 R32: Value: 100k
  R35 R36: Value: 680
R21 R22 R31 R34 = 5W_CEMENT_R: Cement Power Resistor; Component Kind: Standard; Library Name: CustomComponentLibraries.SchLib
U1 = Relay DPDT: DPDT 2 form C (CO) contacts; Component Kind: Standard; Library Name: CustomComponentLibraries.SchLib
